# Altium schematic sheet PyESPCar_Z1.SchDoc
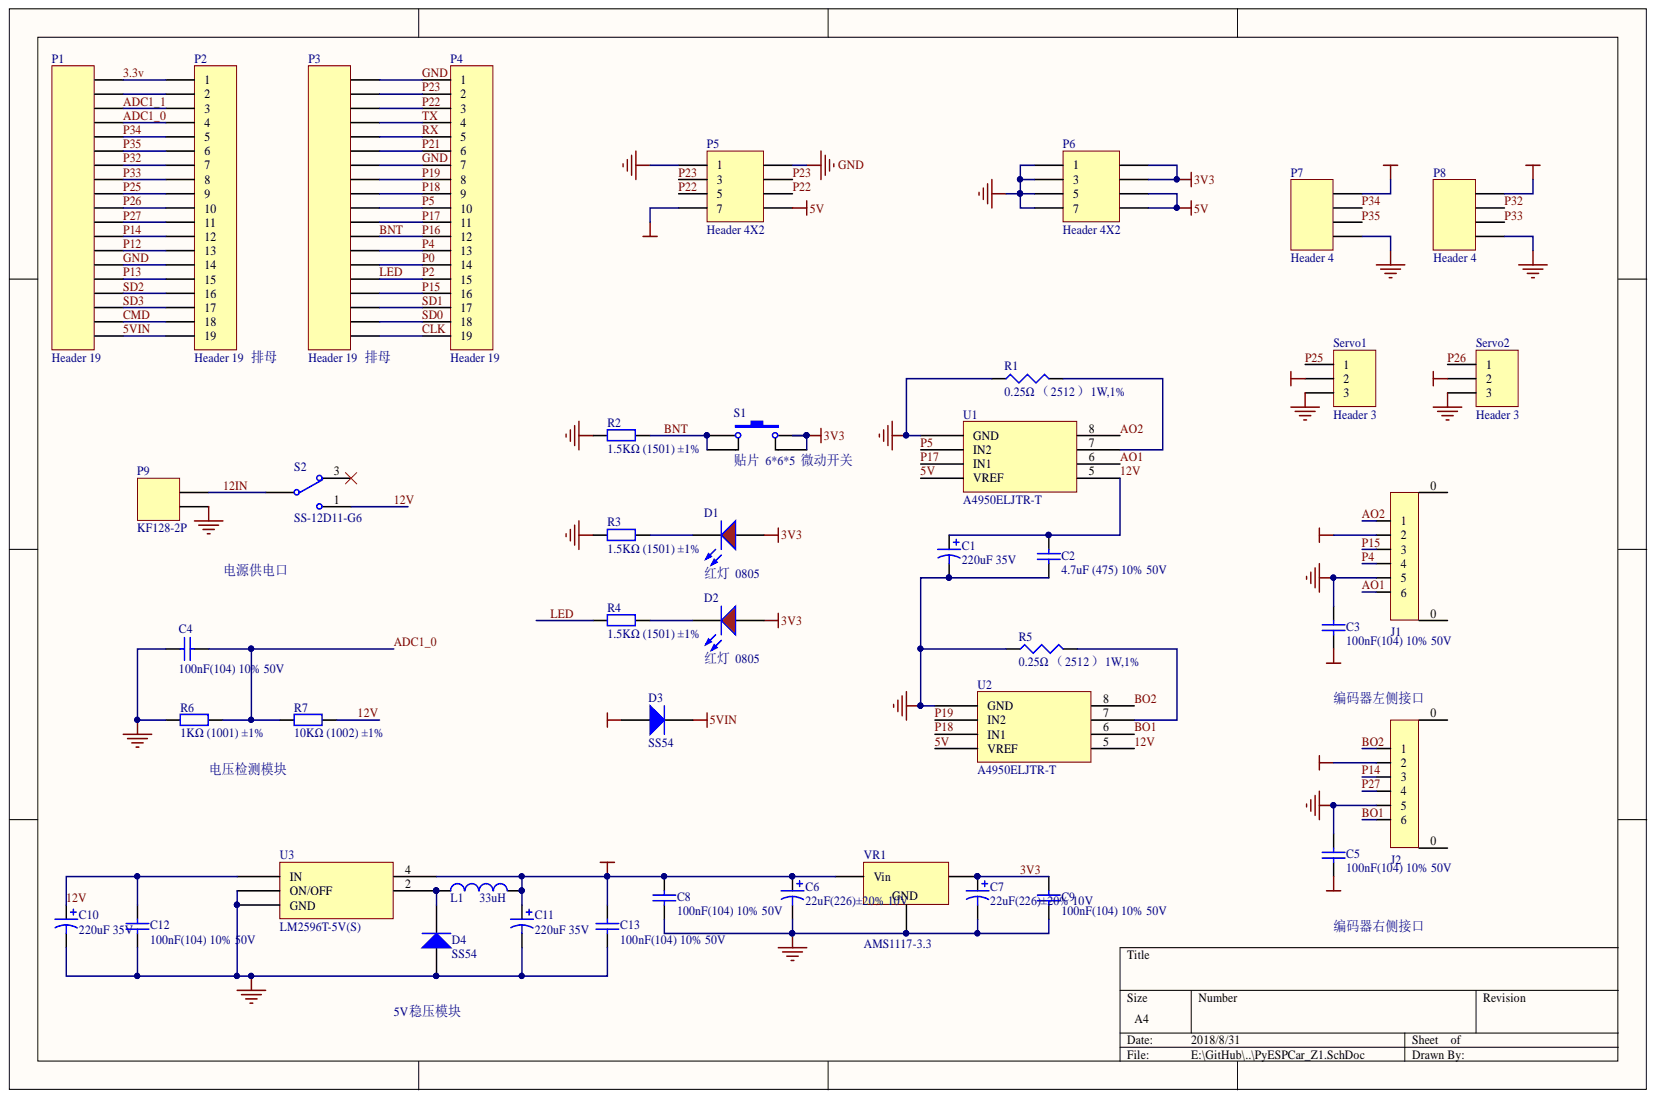
[diagram p0: PyESPCar_Z1.SchDoc]

## Parts
C1  220uF 35V  [CAPM8485X103N]
C2  4.7uF (475) 10% 50V  [1206_C]
C3  100nF(104) 10% 50V  [0805_C]
C4  100nF(104) 10% 50V  [0805_C]
C5  100nF(104) 10% 50V  [0805_C]
C6  22uF(226)±20% 10V  [CAP-3528]
C7  22uF(226)±20% 10V  [CAP-3528]
C8  100nF(104) 10% 50V  [0805_C]
C9  100nF(104) 10% 50V  [0805_C]
C10  220uF 35V  [CAPM8485X103N]
C11  220uF 35V  [CAPM8485X103N]
C12  100nF(104) 10% 50V  [0805_C]
C13  100nF(104) 10% 50V  [0805_C]
D1  红灯 0805  Package: 0805  [0805_LED_S1]
D2  红灯 0805  Package: 0805  [0805_LED_S1]
D3  SS54  [SMA(DO-214AC)_S1]
D4  SS54  [SMA(DO-214AC)_S1]
J1  PH-PS-2.0-6  [PH-PS-2.0-6]  (value not drawn)
J2  PH-PS-2.0-6  [PH-PS-2.0-6]  (value not drawn)
L1  value 33uH  [CAPM100100X103N]  (hidden comment: 33uH ±20%)
P1  Header 19  [HDR1X19]
P2  Header 19 排母  [HDR1X19]
P3  Header 19 排母  [HDR1X19]
P4  Header 19  [HDR1X19]
P5  Header 4X2  [HDR2X4]
P6  Header 4X2  [HDR2X4]
P7  Header 4  [HDR1X4]
P8  Header 4  [HDR1X4]
P9  KF128-2P  [HDR2X1_D1]
R1  0.25Ω（2512）1W,1%  value 0.25Ω  [2512]
R2  1.5KΩ (1501) ±1%  [0805_R]
R3  1.5KΩ (1501) ±1%  [0805_R]
R4  1.5KΩ (1501) ±1%  [0805_R]
R5  0.25Ω（2512）1W,1%  value 0.25Ω  [2512]
R6  1KΩ (1001) ±1%  [0805_R]
R7  10KΩ (1002) ±1%  [0805_R]
S1  贴片 6*6*5 微动开关  [SW_PB4_A1]
S2  SS-12D11-G6  [SW-3]
Servo1  Header 3  [HDR1X3]
Servo2  Header 3  [HDR1X3]
U1  A4950ELJTR-T  [SOP-8_EP_150mil]
U2  A4950ELJTR-T  [SOP-8_EP_150mil]
U3  LM2596T-5V(S)  [TO-263-5]
VR1  AMS1117-3.3  [SOT-223]

## Nets
3.3v: P1.19 P2.1
3V3: C3.2 C5.2 C7.1 C9.2 D1.A D2.A J1.2 J2.2 P5.7 P6.2 P6.4 S1.2 S1.3 VR1.2
5V: C6.1 C8.2 C11.1 C13.2 D3.1 L1.2 P5.8 P6.6 P6.8 P7.4 P8.4 Servo1.2 Servo2.2 U1.4(VREF) U2.4(VREF) U3.4 VR1.3
5VIN: D3.2 P1.1 P2.19
12IN: P9.2 S2.2
12V: C1.1 C2.2 C10.1 C12.2 R7.2 S2.1 U1.5 U2.5 U3.1(IN)
ADC1_0: C4.1 P1.16 P2.4 R6.2 R7.1
ADC1_1: P1.17 P2.3
AO1: J1.6 U1.6
AO2: J1.1 U1.8
BNT: P3.8 P4.12 R2.2 S1.1 S1.4
BO1: J2.6 U2.6
BO2: J2.1 U2.8
CLK: P3.1 P4.19
CMD: P1.2 P2.18
GND: C1.2 C2.1 C3.1 C4.2 C5.1 C6.2 C7.2 C8.1 C9.1 C10.2 C11.2 C12.1 C13.1 D4.1 J1.5 J2.5 P1.6 P2.14 P3.13 P3.19 P4.1 P4.7 P5.1 P5.2 P6.1 P6.3 P6.5 P6.7 P7.1 P8.1 P9.1 R1.1 R2.1 R3.1 R5.1 R6.1 Servo1.3 Servo2.3 U1.1(GND) U2.1(GND) U3.3(GND) U3.5(ON/OFF) VR1.1
LED: P3.5 P4.15 R4.1
P0: P3.6 P4.14
P4: J1.4 P3.7 P4.13
P5: P3.10 P4.10 U1.2(IN2)
P12: P1.7 P2.13
P13: P1.5 P2.15
P14: J2.3 P1.8 P2.12
P15: J1.3 P3.4 P4.16
P17: P3.9 P4.11 U1.3(IN1)
P18: P3.11 P4.9 U2.3(IN1)
P19: P3.12 P4.8 U2.2(IN2)
P21: P3.14 P4.6
P22: P3.17 P4.3 P5.5 P5.6
P23: P3.18 P4.2 P5.3 P5.4
P25: P1.11 P2.9 Servo1.1
P26: P1.10 P2.10 Servo2.1
P27: J2.4 P1.9 P2.11
P32: P1.13 P2.7 P8.3
P33: P1.12 P2.8 P8.2
P34: P1.15 P2.5 P7.3
P35: P1.14 P2.6 P7.2
RX: P3.15 P4.5
SD0: P3.2 P4.18
SD1: P3.3 P4.17
SD2: P1.4 P2.16
SD3: P1.3 P2.17
TX: P3.16 P4.4
NetD1_K: D1.K R3.2
NetD2_K: D2.K R4.2
NetD4_2: D4.2 L1.1 U3.2
NetP1_18: P1.18 P2.2
NetR1_2: R1.2 U1.7
NetR5_2: R5.2 U2.7

Not connected: J1 0; J2 0; S2 3

## Library data of the parts
C1 = Cap Pol1: 贴片电解电容; Component Kind: Standard; LatestRevisionDate: 17-Jul-2002; LatestRevisionNote: Re-released for DXP Platform.; Library Name: Miscellaneous Devices.IntLib; PackageDescription: Polarized Capacitor (Radial); 2 Leads; PackageReference: RB7.6-15; Published: 8-Jun-2000; Value: 220uF35V; Publisher: Altium Limited
C2 = 1206 4.7uF (475) 10% 50V: 贴片电容; Component Kind: Standard; ComponentLink1Description: 供应商链接; ComponentLink1URL: http://www.szlcsc.com/product/details_30577.html; Library Name: Cap.SchLib; Package: 1206; Supplier: LC; SuppliersPartNumber: C29823
C3-C5 C8 C9 C12 C13 = 0805 100nF(104) 10% 50V: 贴片电容; Component Kind: Standard; ComponentLink1Description: 供应商链接; ComponentLink1URL: http://www.szlcsc.com/product/details_50686.html; Library Name: Cap.SchLib; Package: 0805; Supplier: LC; SuppliersPartNumber: C49678
C6 C7 = Cap Pol: 钽电容; Vol: 16V; Component Kind: Standard; LatestRevisionDate: 17-Jul-2002; LatestRevisionNote: Re-released for DXP Platform.; PackageReference: RB7.6-15; Published: 8-Jun-2000; Value: 22uF(226)±20% 10V; Library Name: Fszhang.IntLib; Publisher: Altium Limited
C10 C11 = Cap Pol1: 贴片铝解电容; Component Kind: Standard; LatestRevisionDate: 17-Jul-2002; LatestRevisionNote: Re-released for DXP Platform.; Library Name: Miscellaneous Devices.IntLib; PackageDescription: Polarized Capacitor (Radial); 2 Leads; PackageReference: RB7.6-15; Published: 8-Jun-2000; Value: 220uF35V; Publisher: Altium Limited
D1 D2 = 0805 红灯: 发光二极管; Component Kind: Standard; ComponentLink1Description: 供应商链接; ComponentLink1URL: http://www.szlcsc.com/product/details_2668.html; Library Name: LED.SchLib; Supplier: LC; SuppliersPartNumber: C2295
D3 D4 = SMA(DO-214AC) SS54: 二极管; Component Kind: Standard; ComponentLink1Description: 供应商链接; ComponentLink1URL: http://www.szlcsc.com/product/details_23178.html; Library Name: Diode.SchLib; Package: SMA(DO-214AC); Supplier: LC; SuppliersPartNumber: C22452
L1 = Inductor: 功率电感 MS104R-330MT; Code_IEC: 1005; Code_JEITA: 0402; Component Kind: Standard; LatestRevisionDate: 29-May-2009; LatestRevisionNote: IPC-7351 Footprint Added.; Library Name: Miscellaneous Devices.IntLib; PackageDescription: Chip Inductor; PackageReference: 0402-A; Published: 8-Jun-2000; Signal Integrity: Inductor; Simulation: INDUCTOR; Publisher: Altium Limited
P1 P4 = Header 19: Header, 19-Pin 排针; Component Kind: Standard; LatestRevisionDate: 17-Jul-2002; LatestRevisionNote: Re-released for DXP Platform.; Library Name: Miscellaneous Connectors.IntLib; Signal Integrity: Connector; Publisher: Altium Limited
P2 P3 = Header 19: Header, 19-Pin 排母; Component Kind: Standard; LatestRevisionDate: 17-Jul-2002; LatestRevisionNote: Re-released for DXP Platform.; Library Name: Miscellaneous Connectors.IntLib; Signal Integrity: Connector; Publisher: Altium Limited
P5 P6 = Header 4X2: Header, 4-Pin, Dual row; Component Kind: Standard; LatestRevisionDate: 17-Jul-2002; LatestRevisionNote: Re-released for DXP Platform.; Library Name: Miscellaneous Connectors.IntLib; Signal Integrity: Connector; Publisher: Altium Limited
P7 P8 = Header 4: Header, 4-Pin; Component Kind: Standard; LatestRevisionDate: 17-Jul-2002; LatestRevisionNote: Re-released for DXP Platform.; Library Name: Miscellaneous Connectors.IntLib; Signal Integrity: Connector; Publisher: Altium Limited
P9 = Header 2: 5.08mm端子; Component Kind: Standard; LatestRevisionDate: 17-Jul-2002; LatestRevisionNote: Re-released for DXP Platform.; Library Name: Miscellaneous Connectors.IntLib; Publisher: Altium Limited
R1 R5 = Res3: 超低阻值电阻; Component Kind: Standard; LatestRevisionDate: 29-May-2009; LatestRevisionNote: IPC-7351 Footprint Added.; Library Name: Miscellaneous Devices.IntLib; PackageDescription: Chip Resistor; PackageReference: J1-0603; Published: 8-Jun-2000; Publisher: Altium Limited
R2-R4 = 0603 1.5KΩ (1501) 1%: 贴片电阻; Component Kind: Standard; ComponentLink1Description: 供应商链接; ComponentLink1URL: http://www.szlcsc.com/product/details_23570.html; Library Name: Miscellaneous Devices LC.IntLib; Package: 0603; Supplier: LC; SuppliersPartNumber: C22843
R6 R7 = 0805 1KΩ (1001) 1%: 贴片电阻; Component Kind: Standard; ComponentLink1Description: 供应商链接; ComponentLink1URL: http://www.szlcsc.com/product/details_18201.html; Library Name: RES.SchLib; Package: 0805; Supplier: LC; SuppliersPartNumber: C17513
S1 = SW-PB4: Component Kind: Standard; Description: 贴片 6*6*5 微动开关; LatestRevisionDate: 17-Jul-2002; LatestRevisionNote: Re-released for DXP Platform.; PackageReference: SPST-2; Published: 24-Mar-1999; Library Name: Fszhang.IntLib; Publisher: Altium Limited
S2 = SW-SPDT: 拨动开关2档带固定脚黑色短柄 3脚侧; Component Kind: Standard; ComponentLink1Description: Manufacturer Link; ComponentLink1URL: http://www.apem.com; DatasheetVersion: 05-Dec-2000; LatestRevisionDate: 17-Dec-2003; LatestRevisionNote: Schematic revised, PCB Footprint replaced.; Library Name: Miscellaneous Devices.IntLib; PackageDescription: Switch; 4 Leads; PackageReference: TL36WW15050; Published: 24-Mar-1999; Publisher: Altium Limited
Servo1 Servo2 = Header 3: Header, 3-Pin; Component Kind: Standard; LatestRevisionDate: 17-Jul-2002; LatestRevisionNote: Re-released for DXP Platform.; Library Name: Miscellaneous Connectors.IntLib; Signal Integrity: Connector; Publisher: Altium Limited
U1 = A4950: A4950 电机驱动; Component Kind: Standard; Library Name: lib_all.SchLib
U2 = A4950: A4950电机驱动; Component Kind: Standard; Library Name: lib_all.SchLib
U3 = LM2596T-5V(S): 稳压芯片; Component Kind: Standard; Library Name: lib_all.SchLib
VR1 = SOT-223 AMS1117-3.3: 低压差线性稳压(LDO); Component Kind: Standard; ComponentLink1Description: 供应商链接; ComponentLink1URL: http://www.szlcsc.com/product/details_6652.html; Library Name: Miscellaneous Devices LC.IntLib; Package: SOT-223; Supplier: LC; SuppliersPartNumber: C6186
J1 J2 = PH-PS-2.0-6: Component Kind: Standard; Description: PH-PS-2.0-6; Library Name: Connector.SchLib
